# Altium schematic sheet bmsMaster_power.SchDoc
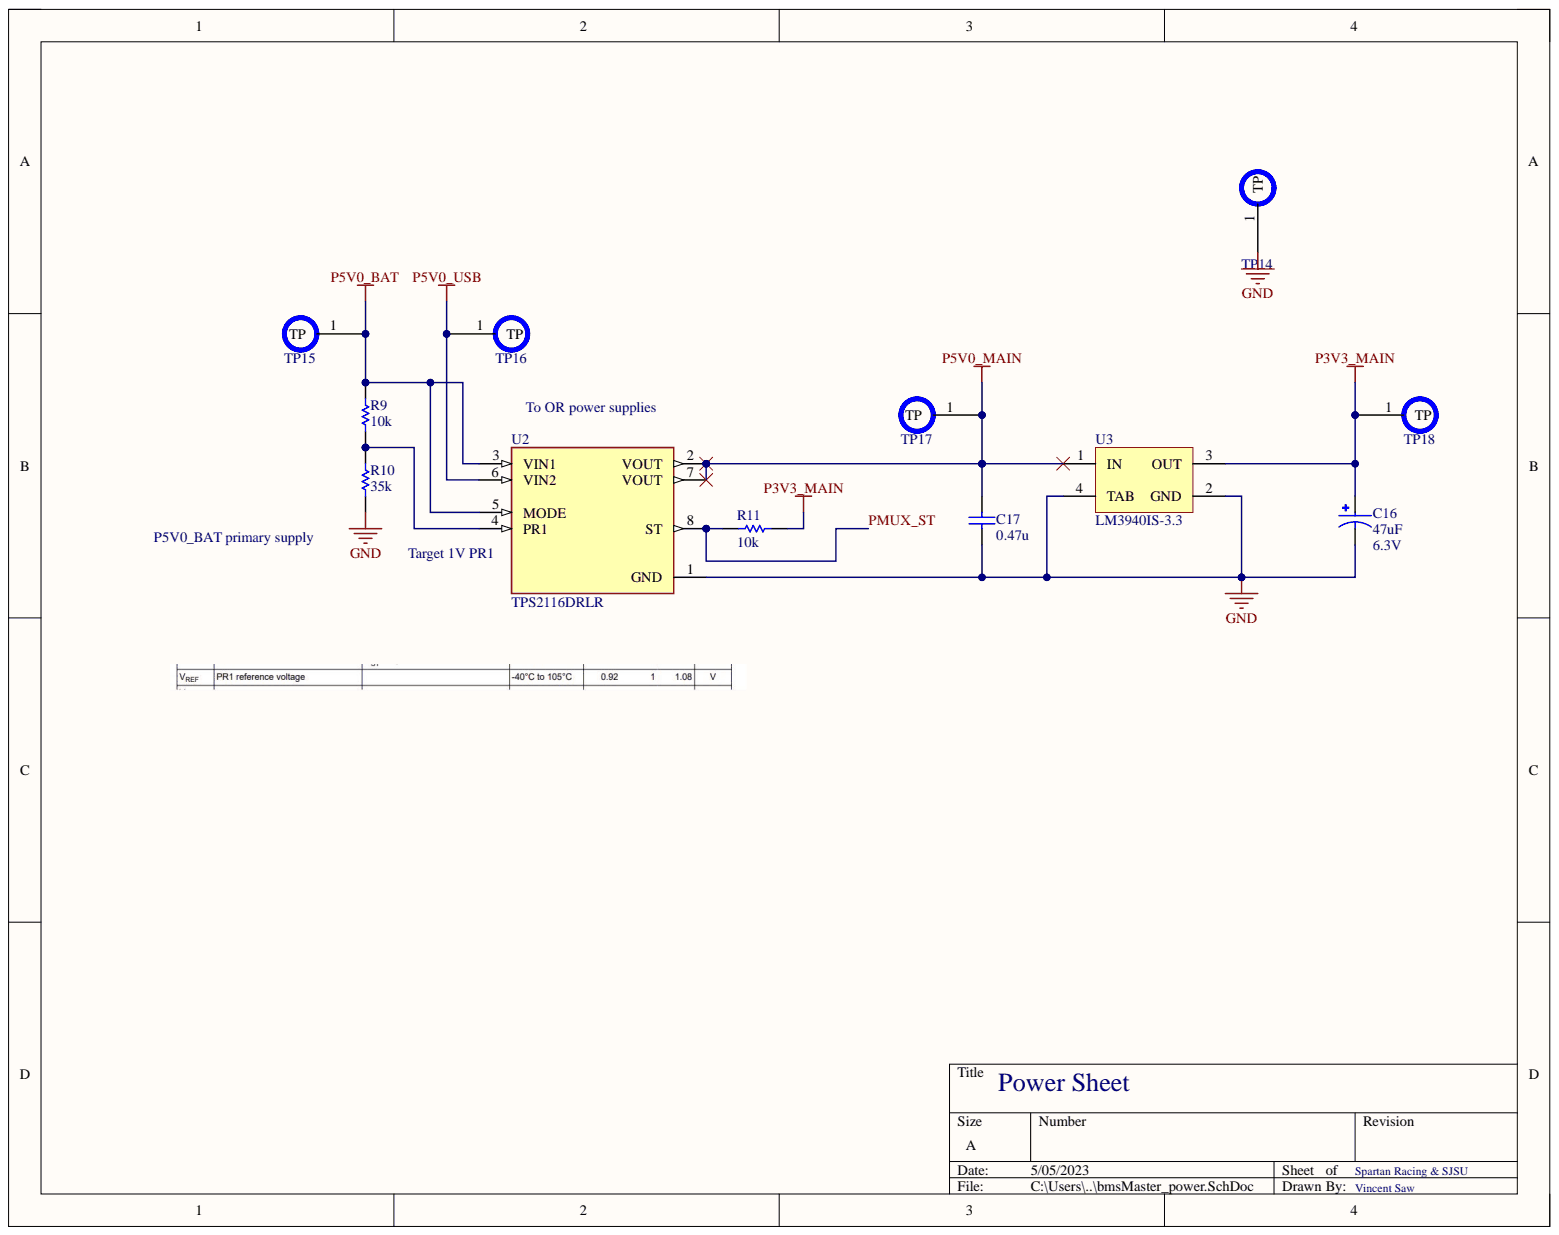
[diagram p0: bmsMaster_power.SchDoc]

## Parts
C16  value 47uF  Rating: 6.3V  [CAP_EEEHA0J470WR]  (hidden comment: EEEHA0J470WR)
C17  value 0.47u  [CAPC1608X90N]  (hidden comment: Capacitor)
R9  value 10k  [RESC1608X50N]  (hidden comment: Resistor)
R10  value 35k  [RESC1608X50N]  (hidden comment: Resistor)
R11  value 10k  [RESC1608X50N]  (hidden comment: Resistor)
TP14  TSTPT  [Testpoint1]  (value not drawn)  (no BOM)
TP15  TSTPT  [Testpoint1]  (value not drawn)  (no BOM)
TP16  TSTPT  [Testpoint1]  (value not drawn)  (no BOM)
TP17  TSTPT  [Testpoint1]  (value not drawn)  (no BOM)
TP18  TSTPT  [Testpoint1]  (value not drawn)  (no BOM)
U2  TPS2116DRLR  [SOTFL50P160X60-8N]
U3  LM3940IS-3.3  [KTT0003B_N]

## Nets
GND: C16.2 C17.1 R10.1 TP14.1 U2.1(GND) U3.2(GND) U3.4(TAB)
P3V3_MAIN: C16.1 R11.1 TP18.1 U3.3(OUT)
P5V0_BAT: R9.2 TP15.1 U2.3(VIN1) U2.5(MODE)
P5V0_MAIN: C17.2 TP17.1 U2.2(VOUT) U2.7(VOUT) U3.1(IN)
P5V0_USB: TP16.1 U2.6(VIN2)
PMUX_ST: R11.2 U2.8(ST)
NetR9_1: R9.1 R10.2 U2.4(PR1)

## Library data of the parts
C16 = EEEHA0J470WR: MF: {'manufacturer': u'Panasonic'}; Availability: Unavailable; Component Kind: Standard; MP: EEEHA0J470WR; Package: None; Price: None
C17 = Capacitor: SimulationGenericComponent: True; Component Kind: Standard; Description: Capacitor; Library Name: Simulation Generic Components.IntLib; Simulation: C_Capacitor_Default
R9-R11 = Resistor: SimulationGenericComponent: True; TC1: 0; Component Kind: Standard; Description: Resistor; Library Name: Simulation Generic Components.IntLib; Simulation: R_Resistor_Default; TC2: 0
TP14-TP18 = Testpoint1: Library Name: testpoint1.SchLib
U2 = TPS2116DRLR: 1.6 V to 5.5 V, 2.5-A Low IQ Power Mux with Manual and Priority Switchover; MF: {'manufacturer': u'Texas Instruments'}; Availability: Unavailable; Component Kind: Standard; MP: TPS2116DRLR; Package: None; Price: None
U3 = CMP-0062-00201-4: 1A Low Dropout Regulator for 5V to 3.3V Conversion, 3-pin TO-263; Manufacturer: Texas Instruments; CompType: LDO IC; PackageTopMarking: NSUZXYTTE#*LM3940IS*-3.3 P+; PowerWise: N; Code_JEDEC: MO-169-AA; Component Kind: Standard; ComponentLink1Description: Datasheet URL; ComponentLink1URL: http://www.ti.com/general/docs/lit/getliterature.tsp?genericPartNumber=LM3940&fileType=pdf; Library Name: Altium Content Vault; PackageDescription: TO-263, Molded, .400 x .390 x .180in, 3 Lead, .1in Pitch, Surface Mount; PackageReference: KTT0003B; PinCount: 3; RoHS: N
